# Altium schematic sheet [9]-MODEM_POWER.SchDoc([9]-MODEM_POWER)
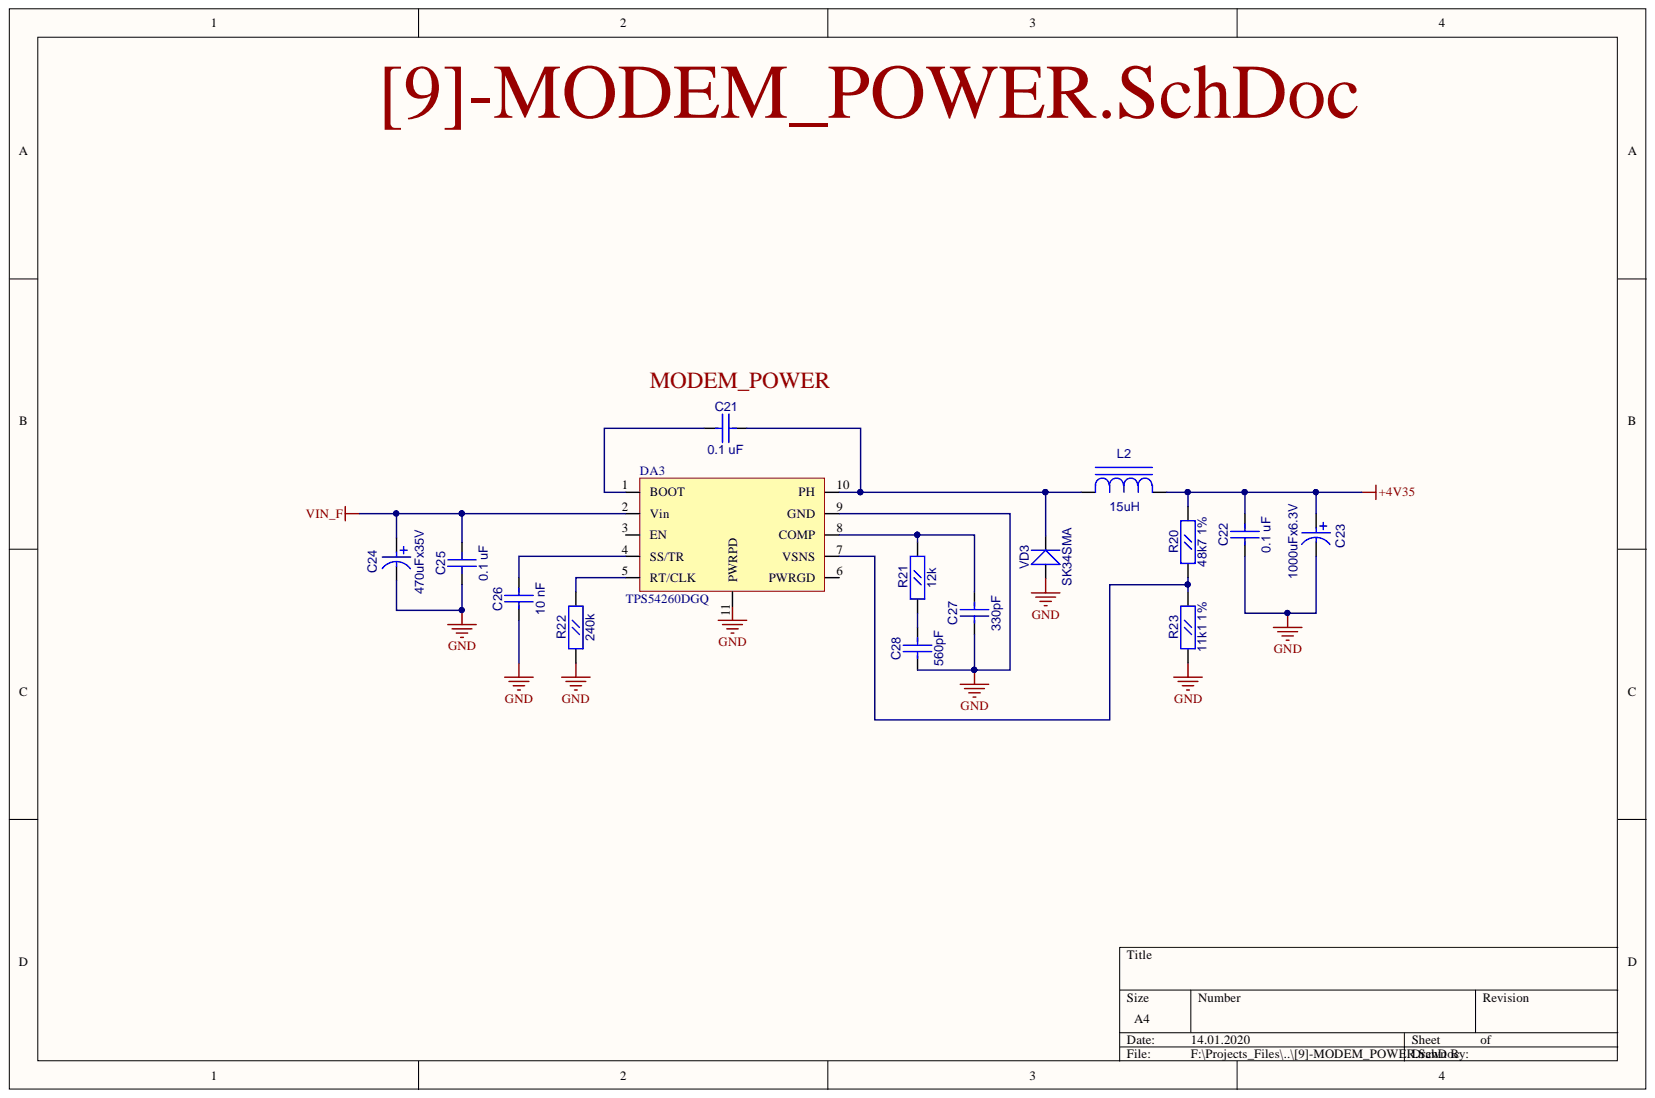
[diagram p0: [9]-MODEM_POWER.SchDoc]

## Parts
C21  0.1 uF  [CC0603]
C22  0.1 uF  [CC0603]
C23  1000uFx6.3V  [CC8X3.5]
C24  470uFx35V  [CC16X10_CAP0.5(1)(Primary)]
C25  0.1 uF  [CC0603]
C26  10 nF  [CC0603]
C27  330pF  [CC0603]
C28  560pF  [CC0603]
DA3  TPS54260DGQ  [S-PDSO-G10]
L2  15uH  [SDR0805]
R20  48k7 1%  [RC0603]
R21  12k  [RC0603]
R22  240k  [RC0603]
R23  11k1 1%  [RC0603]
VD3  SK34SMA  [SMA]

## Nets
+4V35: C22.1 C23.1 L2.2 R20.2
GND: C22.2 C23.2 C24.1 C25.2 C26.2 C27.2 C28.2 DA3.9(GND) DA3.11(PWRPD) R22.1 R23.1 VD3.1
VIN_F: C24.2 C25.1 DA3.2(Vin)
NetC21_1: C21.1 DA3.10(PH) L2.1 VD3.2
NetC21_2: C21.2 DA3.1(BOOT)
NetC26_1: C26.1 DA3.4(SS/TR)
NetC27_1: C27.1 DA3.8(COMP) R21.2
NetC28_1: C28.1 R21.1
NetDA3_5: DA3.5(RT/CLK) R22.2
NetDA3_7: DA3.7(VSNS) R20.1 R23.2

Not connected: DA3 3(EN) 6(PWRGD)

## Library data of the parts
C21 C22 C25-C28 = CC0603: Description: CC0603; Component Kind: Standard; Library Name: gprs_modem_2.SchLib
  C21 C22 C25: Value: 0.1 uF
  C26: Value: 10 nF
  C27: Value: 330pF
  C28: Value: 560pF
C23 = CAP0.35: Value: 1000uFx6.3V; Description: CAP0.35; Component Kind: Standard; Library Name: gprs_modem_2.SchLib
C24 = CAP0.5(1): Value: 470uFx35V; Description: CAP0.5(1); Component Kind: Standard; Library Name: gprs_modem_2.SchLib
L2 = SDR0805: Value: 15uH; Description: SDR0805; Component Kind: Standard; Library Name: gprs_modem_2.SchLib
R20-R23 = RC0603: Description: RC0603; Component Kind: Standard; Library Name: gprs_modem_2.SchLib
  R20: Value: 48k7 1%
  R21: Value: 12k
  R22: Value: 240k
  R23: Value: 11k1 1%
VD3 = SK34SMA: Schottky Rectifier; Value: {Value}; Component Kind: Standard; Library Name: gprs_modem.SchLib
DA3 = TPS54260DGQ: Component Kind: Standard; Library Name: gprs_modem_2.SchLib
